# Altium schematic sheet Grounds and Sensing.SchDoc("Grounds and Sensing")
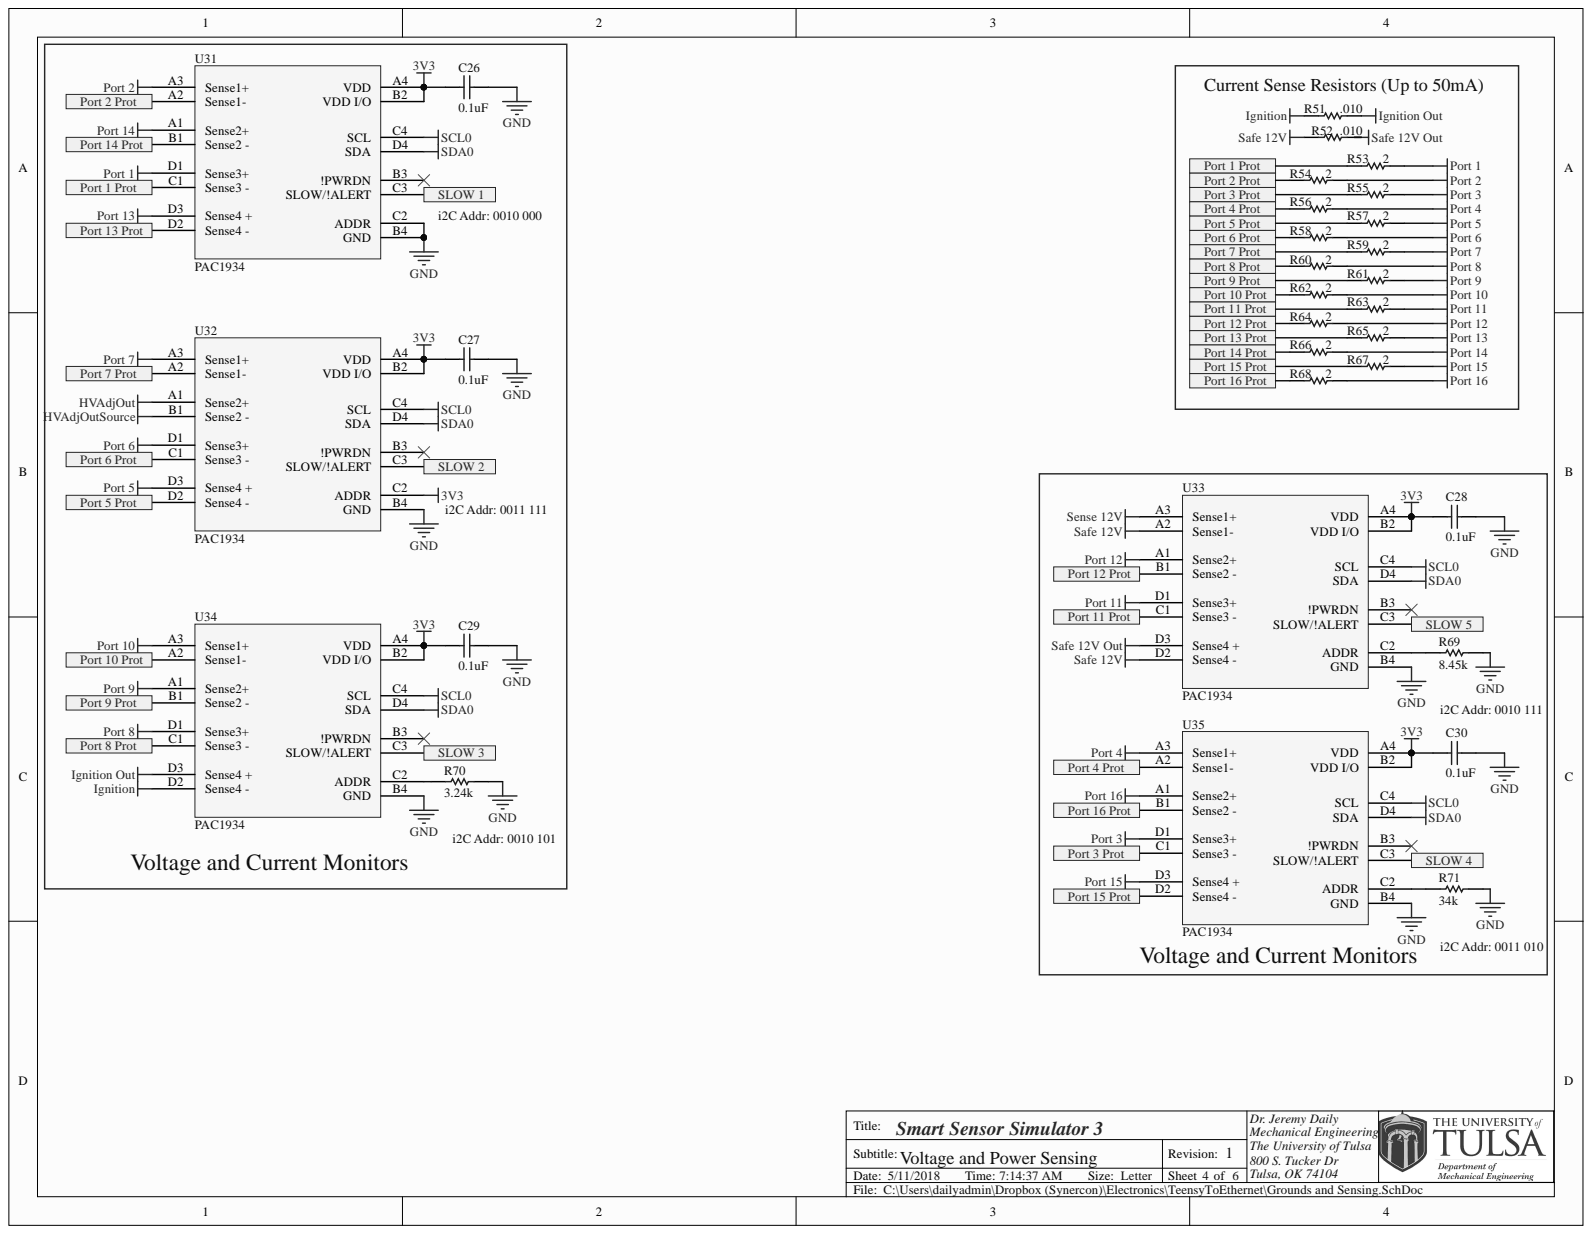
[diagram p0: Grounds and Sensing.SchDoc]

## Parts
C26  0.1uF  [0603]
C27  0.1uF  [0603]
C28  0.1uF  [0603]
C29  0.1uF  [0603]
C30  0.1uF  [0603]
R51  .010  [0805]
R52  .010  [0805]
R53  2  [0805]
R54  2  [0805]
R55  2  [0805]
R56  2  [0805]
R57  2  [0805]
R58  2  [0805]
R59  2  [0805]
R60  2  [0805]
R61  2  [0805]
R62  2  [0805]
R63  2  [0805]
R64  2  [0805]
R65  2  [0805]
R66  2  [0805]
R67  2  [0805]
R68  2  [0805]
R69  8.45k  [0603]
R70  3.24k  [0603]
R71  34k  [0603]
U31  PAC1934  [BGA16x4]
U32  PAC1934  [BGA16x4]
U33  PAC1934  [BGA16x4]
U34  PAC1934  [BGA16x4]
U35  PAC1934  [BGA16x4]

## Ports
Port 1 Prot, Port 2 Prot, Port 3 Prot, Port 4 Prot, Port 5 Prot, Port 6 Prot, Port 7 Prot, Port 8 Prot, Port 9 Prot, Port 10 Prot, Port 11 Prot, Port 12 Prot, Port 13 Prot, Port 14 Prot, Port 15 Prot, Port 16 Prot, SLOW 1, SLOW 2, SLOW 3, SLOW 4, SLOW 5

## Nets
3V3: C26.2 C27.2 C28.2 C29.2 C30.2 U31.A4(VDD) U31.B2(VDD_I/O) U32.A4(VDD) U32.B2(VDD_I/O) U32.C2(ADDR) U33.A4(VDD) U33.B2(VDD_I/O) U34.A4(VDD) U34.B2(VDD_I/O) U35.A4(VDD) U35.B2(VDD_I/O)
GND: C26.1 C27.1 C28.1 C29.1 C30.1 R69.2 R70.2 R71.2 U31.B4(GND) U31.C2(ADDR) U32.B4(GND) U33.B4(GND) U34.B4(GND) U35.B4(GND)
HVAdjOut: U32.A1(Sense2+)
HVAdjOutSource: U32.B1(Sense2_-)
Ignition: R51.2 U34.D2(Sense4_-)
Ignition Out: R51.1 U34.D3(Sense4_+)
Port 1: R53.1 U31.D1(Sense3+)
Port 2: R54.1 U31.A3(Sense1+)
Port 3: R55.1 U35.D1(Sense3+)
Port 4: R56.1 U35.A3(Sense1+)
Port 5: R57.1 U32.D3(Sense4_+)
Port 6: R58.1 U32.D1(Sense3+)
Port 7: R59.1 U32.A3(Sense1+)
Port 8: R60.1 U34.D1(Sense3+)
Port 9: R61.1 U34.A1(Sense2+)
Port 10: R62.1 U34.A3(Sense1+)
Port 11: R63.1 U33.D1(Sense3+)
Port 12: R64.1 U33.A1(Sense2+)
Port 13: R65.1 U31.D3(Sense4_+)
Port 14: R66.1 U31.A1(Sense2+)
Port 15: R67.1 U35.D3(Sense4_+)
Port 16: R68.1 U35.A1(Sense2+)
Safe 12V: R52.2 U33.A2(Sense1-) U33.D2(Sense4_-)
Safe 12V Out: R52.1 U33.D3(Sense4_+)
SCL0: U31.C4(SCL) U32.C4(SCL) U33.C4(SCL) U34.C4(SCL) U35.C4(SCL)
SDA0: U31.D4(SDA) U32.D4(SDA) U33.D4(SDA) U34.D4(SDA) U35.D4(SDA)
Sense 12V: U33.A3(Sense1+)
Port 1 Prot: R53.2 U31.C1(Sense3_-) ; port Port 1 Prot
Port 2 Prot: R54.2 U31.A2(Sense1-) ; port Port 2 Prot
Port 3 Prot: R55.2 U35.C1(Sense3_-) ; port Port 3 Prot
Port 4 Prot: R56.2 U35.A2(Sense1-) ; port Port 4 Prot
Port 5 Prot: R57.2 U32.D2(Sense4_-) ; port Port 5 Prot
Port 6 Prot: R58.2 U32.C1(Sense3_-) ; port Port 6 Prot
Port 7 Prot: R59.2 U32.A2(Sense1-) ; port Port 7 Prot
Port 8 Prot: R60.2 U34.C1(Sense3_-) ; port Port 8 Prot
Port 9 Prot: R61.2 U34.B1(Sense2_-) ; port Port 9 Prot
Port 10 Prot: R62.2 U34.A2(Sense1-) ; port Port 10 Prot
Port 11 Prot: R63.2 U33.C1(Sense3_-) ; port Port 11 Prot
Port 12 Prot: R64.2 U33.B1(Sense2_-) ; port Port 12 Prot
Port 13 Prot: R65.2 U31.D2(Sense4_-) ; port Port 13 Prot
Port 14 Prot: R66.2 U31.B1(Sense2_-) ; port Port 14 Prot
Port 15 Prot: R67.2 U35.D2(Sense4_-) ; port Port 15 Prot
Port 16 Prot: R68.2 U35.B1(Sense2_-) ; port Port 16 Prot
SLOW 1: U31.C3(SLOW/!ALERT) ; port SLOW 1
SLOW 2: U32.C3(SLOW/!ALERT) ; port SLOW 2
SLOW 3: U34.C3(SLOW/!ALERT) ; port SLOW 3
SLOW 4: U35.C3(SLOW/!ALERT) ; port SLOW 4
SLOW 5: U33.C3(SLOW/!ALERT) ; port SLOW 5
NetR69_1: R69.1 U33.C2(ADDR)
NetR70_1: R70.1 U34.C2(ADDR)
NetR71_1: R71.1 U35.C2(ADDR)

Not connected: U31 B3(!PWRDN); U32 B3(!PWRDN); U33 B3(!PWRDN); U34 B3(!PWRDN); U35 B3(!PWRDN)

## Library data of the parts
C26-C30 = Cap: 0.1µF -20%, +80% 25V Ceramic Capacitor Y5V (F) 0603 (1608 Metric); PartNumber: 06033G104ZAT2A; Manufacturer: AVX Corporation; Alternative: Acceptable; Supplier Part Number 1: 478-1259-1-ND; Supplier 1: Digi-Key; Simulation: CAP; Signal Integrity: Cap; Library Name: SSS Integrated Library.IntLib; Component Kind: Standard
R51 R52 = Chip Resistor: 10 mOhms ±1% 0.5W, 1/2W Chip Resistor 0805 (2012 Metric) Automotive AEC-Q200, Current Sense Thick Film; PartNumber: ERJ-6BWFR010V; Manufacturer: Panasonic Electronic Components; Alternative: None; Supplier Part Number 1: 667-ERJ-6BWFR010V; Supplier 1: Mouser; Library Name: SSS Integrated Library.IntLib; Component Kind: Standard
R53-R68 = Chip Resistor: 2 Ohms ±1% 0.5W, 1/2W Chip Resistor 0805 (2012 Metric) Automotive AEC-Q200, Current Sense Thick Film; PartNumber: ERJ-6DQF2R0V; Manufacturer: Panasonic Electronic Components; Alternative: None; Supplier Part Number 1: 667-ERJ-6DQF2R0V; Supplier 1: Mouser; Library Name: SSS Integrated Library.IntLib; Component Kind: Standard
R69 = Chip Resistor: 4.7 kOhms ±1% 0.1W, 1/10W Chip Resistor 0603 (1608 Metric) Automotive AEC-Q200 Thick Film; PartNumber: ERJ-3EKF4701V; Manufacturer: Panasonic Electronic Components; Alternative: Acceptable; Supplier Part Number 1: P4.70KHCT-ND; Supplier 1: Digi-Key; Library Name: SSS Integrated Library.IntLib; Component Kind: Standard
R70 = Chip Resistor: 3.24 kOhms ±1% 0.1W, 1/10W Chip Resistor 0603 (1608 Metric) Automotive AEC-Q200 Thick Film; PartNumber: ERJ-3EKF3241V; Manufacturer: Panasonic Electronic Components; Alternative: None; Supplier Part Number 1: P3.24KHCT-ND; Supplier 1: Digi-Key; Library Name: SSS Integrated Library.IntLib; Component Kind: Standard
R71 = Chip Resistor: 34 kOhms ±1% 0.1W, 1/10W Chip Resistor 0603 (1608 Metric) Automotive AEC-Q200 Thick Film; PartNumber: ERJ-3EKF3402V; Manufacturer: Panasonic Electronic Components; Alternative: Acceptable; Supplier Part Number 1: P34.0KHCT-ND; Supplier 1: Digi-Key; Library Name: SSS Integrated Library.IntLib; Component Kind: Standard
U31-U35 = PAC1934: Current Monitor Regulator High-Side 16-WLCSP (2.23x2.17); PartNumber: PAC1934T-I/J6CX; Manufacturer: Microchip Technology; Alternative: None; Supplier Part Number 1: PAC1934T-I/J6CX-CT-ND; Supplier 1: Digi-Key; Library Name: TU Custom Parts Schematics.SchLib; Component Kind: Standard
